# Altium schematic sheet HMIv3.SchDoc
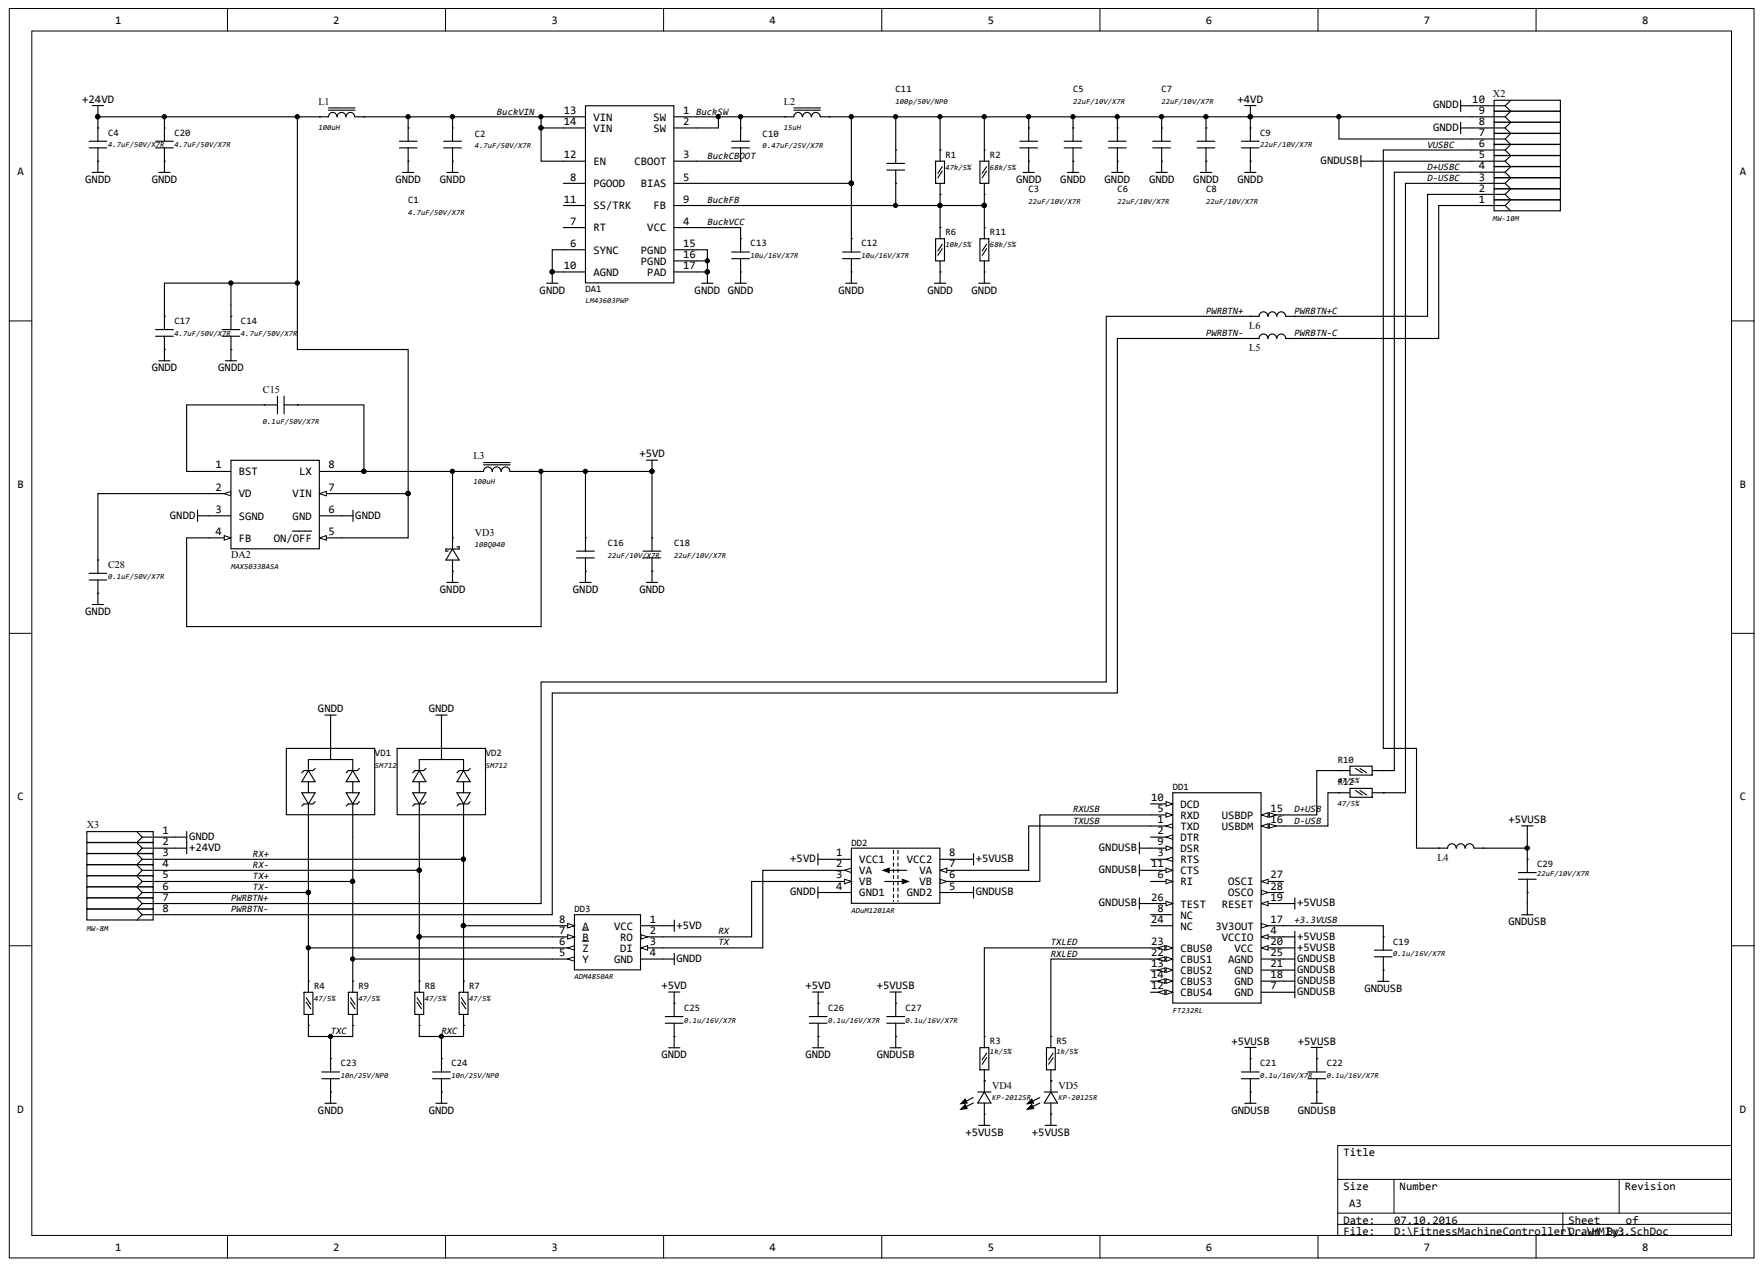
[diagram p0: HMIv3.SchDoc]

## Parts
C1  4.7uF/50V/X7R
C2  4.7uF/50V/X7R
C3  22uF/10V/X7R
C4  4.7uF/50V/X7R
C5  22uF/10V/X7R
C6  22uF/10V/X7R
C7  22uF/10V/X7R
C8  22uF/10V/X7R
C9  22uF/10V/X7R
C10  0.47uF/25V/X7R
C11  100p/50V/NP0
C12  10u/16V/X7R
C13  10u/16V/X7R
C14  4.7uF/50V/X7R
C15  0.1uF/50V/X7R
C16  22uF/10V/X7R
C17  4.7uF/50V/X7R
C18  22uF/10V/X7R
C19  0.1u/16V/X7R
C20  4.7uF/50V/X7R
C21  0.1u/16V/X7R
C22  0.1u/16V/X7R
C23  10n/25V/NP0
C24  10n/25V/NP0
C25  0.1u/16V/X7R
C26  0.1u/16V/X7R
C27  0.1u/16V/X7R
C28  0.1uF/50V/X7R
C29  22uF/10V/X7R
DA1  LM43603PWP
DA2  MAX5033BASA
DD1  FT232RL
DD2  ADuM1201AR
DD3  ADM4850AR
L1  100uH
L2  15uH
L3  100uH
L4  BLM18  (value not drawn)
L5  BLM18  (value not drawn)
L6  BLM18  (value not drawn)
R1  47k/5%
R2  68k/5%
R3  1k/5%
R4  47/5%
R5  1k/5%
R6  10k/5%
R7  47/5%
R8  47/5%
R9  47/5%
R10  47/5%
R11  68k/5%
R12  47/5%
VD1  SM712
VD2  SM712
VD3  10BQ040
VD4  KP-2012SR
VD5  KP-2012SR
X2  MW-10M
X3  MW-8M

## Nets
+4VD: C3.2 C5.2 C6.2 C7.2 C8.2 C9.2 C11.2 C12.2 DA1.5(BIAS) L2.2 R1.2 R2.2 X2.7 X2.9
+5VD: C16.2 C18.2 C25.2 C26.2 DA2.4(FB) DD2.1(VCC1) DD3.1(VCC) L3.2
+5VUSB: C21.2 C22.2 C27.2 C29.2 DD1.4(VCCIO) DD1.19(RESET) DD1.20(VCC) DD2.8(VCC2) L4.2 VD4.1 VD5.1
+24VD: C4.2 C14.2 C17.2 C20.2 DA2.5(ON/OFF) DA2.7(VIN) L1.1 X3.2
GNDD: C1.1 C2.1 C3.1 C4.1 C5.1 C6.1 C7.1 C8.1 C9.1 C12.1 C13.1 C14.1 C16.1 C17.1 C18.1 C20.1 C23.1 C24.1 C25.1 C26.1 C28.2 DA1.6(SYNC) DA1.10(AGND) DA1.15(PGND) DA1.16(PGND) DA1.17(PAD) DA2.3(SGND) DA2.6(GND) DD2.4(GND1) DD3.4(GND) R6.1 R11.1 VD1.3 VD2.3 VD3.1 X2.8 X2.10 X3.1
GNDUSB: C19.1 C21.1 C22.1 C27.1 C29.1 DD1.7(GND) DD1.9(DSR) DD1.11(CTS) DD1.18(GND) DD1.21(GND) DD1.25(AGND) DD1.26(TEST) DD2.5(GND2) X2.5
BUCKCBOOT: C10.1 DA1.3(CBOOT)
BUCKFB: C11.1 DA1.9(FB) R1.1 R2.1 R6.2 R11.2
BUCKSW: C10.2 DA1.1(SW) DA1.2(SW) L2.1
BUCKVCC: C13.2 DA1.4(VCC)
BUCKVIN: C1.2 C2.2 DA1.12(EN) DA1.13(VIN) DA1.14(VIN) L1.2
D+USB: DD1.15(USBDP) R10.1
D+USBC: R10.2 X2.4
D-USB: DD1.16(USBDM) R12.1
D-USBC: R12.2 X2.3
PWRBTN+: L6.1 X3.7
PWRBTN+C: L6.2 X2.2
PWRBTN-: L5.1 X3.8
PWRBTN-C: L5.2 X2.1
RX: DD2.3(VB) DD3.2(RO)
RX+: DD3.8(A) R7.2 VD2.1 X3.3
RX-: DD3.7(B) R8.2 VD2.2 X3.4
RXC: C24.2 R7.1 R8.1
RXLED: DD1.22(CBUS1) R5.1
RXUSB: DD1.5(RXD) DD2.6(VB)
TX: DD2.2(VA) DD3.3(DI)
TX+: DD3.5(Y) R9.2 VD1.1 X3.5
TX-: DD3.6(Z) R4.2 VD1.2 X3.6
TXC: C23.2 R4.1 R9.1
TXLED: DD1.23(CBUS0) R3.1
TXUSB: DD1.1(TXD) DD2.7(VA)
VUSBC: L4.1 X2.6
NetC15_1: C15.1 DA2.8(LX) L3.1 VD3.2
NetC15_2: C15.2 DA2.1(BST)
NetC19_2: C19.2 DD1.17(3V3OUT)
NetC28_1: C28.1 DA2.2(VD)
NetR3_2: R3.2 VD4.2
NetR5_2: R5.2 VD5.2
+3.3VUSB:

Not connected: DA1 7(RT) 8(PGOOD) 11(SS/TRK); DD1 2(DTR) 3(RTS) 6(RI) 8(NC) 10(DCD) 12(CBUS4) 13(CBUS2) 14(CBUS3) 24(NC) 27(OSCI) 28(OSCO)

## Library data of the parts
C1-C9 C14 C16-C18 C20 C29 = (no symbol name): ComponentDescriptionRUS: Конденсатор SMD 1210; Analog: нет; NumberSolderPointsSMD: 0; NumberSolderPointsTHT: 0
C10 = (no symbol name): ComponentDescriptionRUS: Конденсатор SMD 0805; Analog: нет; NumberSolderPointsSMD: 0; NumberSolderPointsTHT: 0
C11 C23 C24 = (no symbol name): Описание: Конденсатор SMD 0603; NumberSolderPointsSMD: 0; Аналог: нет; NumberSolderPointsTHT: 0
C12 C13 = (no symbol name): ComponentDescriptionRUS: Конденсатор SMD 1206; Analog: нет; NumberSolderPointsSMD: 0; NumberSolderPointsTHT: 0
C15 C28 = (no symbol name): Описание: Конденсатор SMD 0805; NumberSolderPointsSMD: 0; Аналог: нет; NumberSolderPointsTHT: 0
C19 C21 C22 C25-C27 = (no symbol name): ComponentDescriptionRUS: Конденсатор SMD 0603; Analog: нет; NumberSolderPointsSMD: 0; NumberSolderPointsTHT: 0
DA1 = (no symbol name): ComponentDescriptionRUS: Микросхема TSSOP16
DA2 = (no symbol name): NumberSolderPointsSMD: 8; NumberSolderPointsTHT: 0; Аналог: нет; Комплект: *
DD1 = (no symbol name): ComponentDescriptionRUS: Микросхема SSOP28; Analog: нет; NumberSolderPointsSMD: 0; NumberSolderPointsTHT: 0
DD2 = (no symbol name): ComponentDescriptionRUS: Микросхема SO8; Analog: нет; NumberSolderPointsSMD: 0; NumberSolderPointsTHT: 0
DD3 = (no symbol name): ComponentDescriptionRUS: Микросхема SO8; Аналог: нет; NumberSolderPointsSMD: 0; NumberSolderPointsTHT: 0
L1-L3 = (no symbol name): Описание: Индуктивность SMD 2525; NumberSolderPointsSMD: 0; Аналог: нет; NumberSolderPointsTHT: 0
L4-L6 = (no symbol name): Описание: Фильтр SMD 0603; NumberSolderPointsSMD: 0; Аналог: нет; x: BLM18AG121SN1D; NumberSolderPointsTHT: 0
R1-R12 = (no symbol name): ComponentDescriptionRUS: Резистор SMD 0603; Analog: нет; NumberSolderPointsSMD: 0; NumberSolderPointsTHT: 0
VD1-VD3 = (no symbol name): Описание: Диод SMB; NumberSolderPointsSMD: 0; Аналог: нет; NumberSolderPointsTHT: 0
VD4 VD5 = (no symbol name): Описание: Светодиод SMD 0805; NumberSolderPointsSMD: 0; Аналог: нет; NumberSolderPointsTHT: 0
X2 = (no symbol name): Описание: Разъем, вилка на плату, шаг 2.0мм; NumberSolderPointsSMD: 10; NumberSolderPointsTHT: 0; Аналог: нет; Комплект: MU-10F
X3 = (no symbol name): Описание: Разъем, вилка на плату, шаг 2.0мм; NumberSolderPointsSMD: 8; NumberSolderPointsTHT: 0; Аналог: нет; Комплект: MU-8F
